# Altium schematic sheet [12] SPI.SchDoc("[12] SPI")
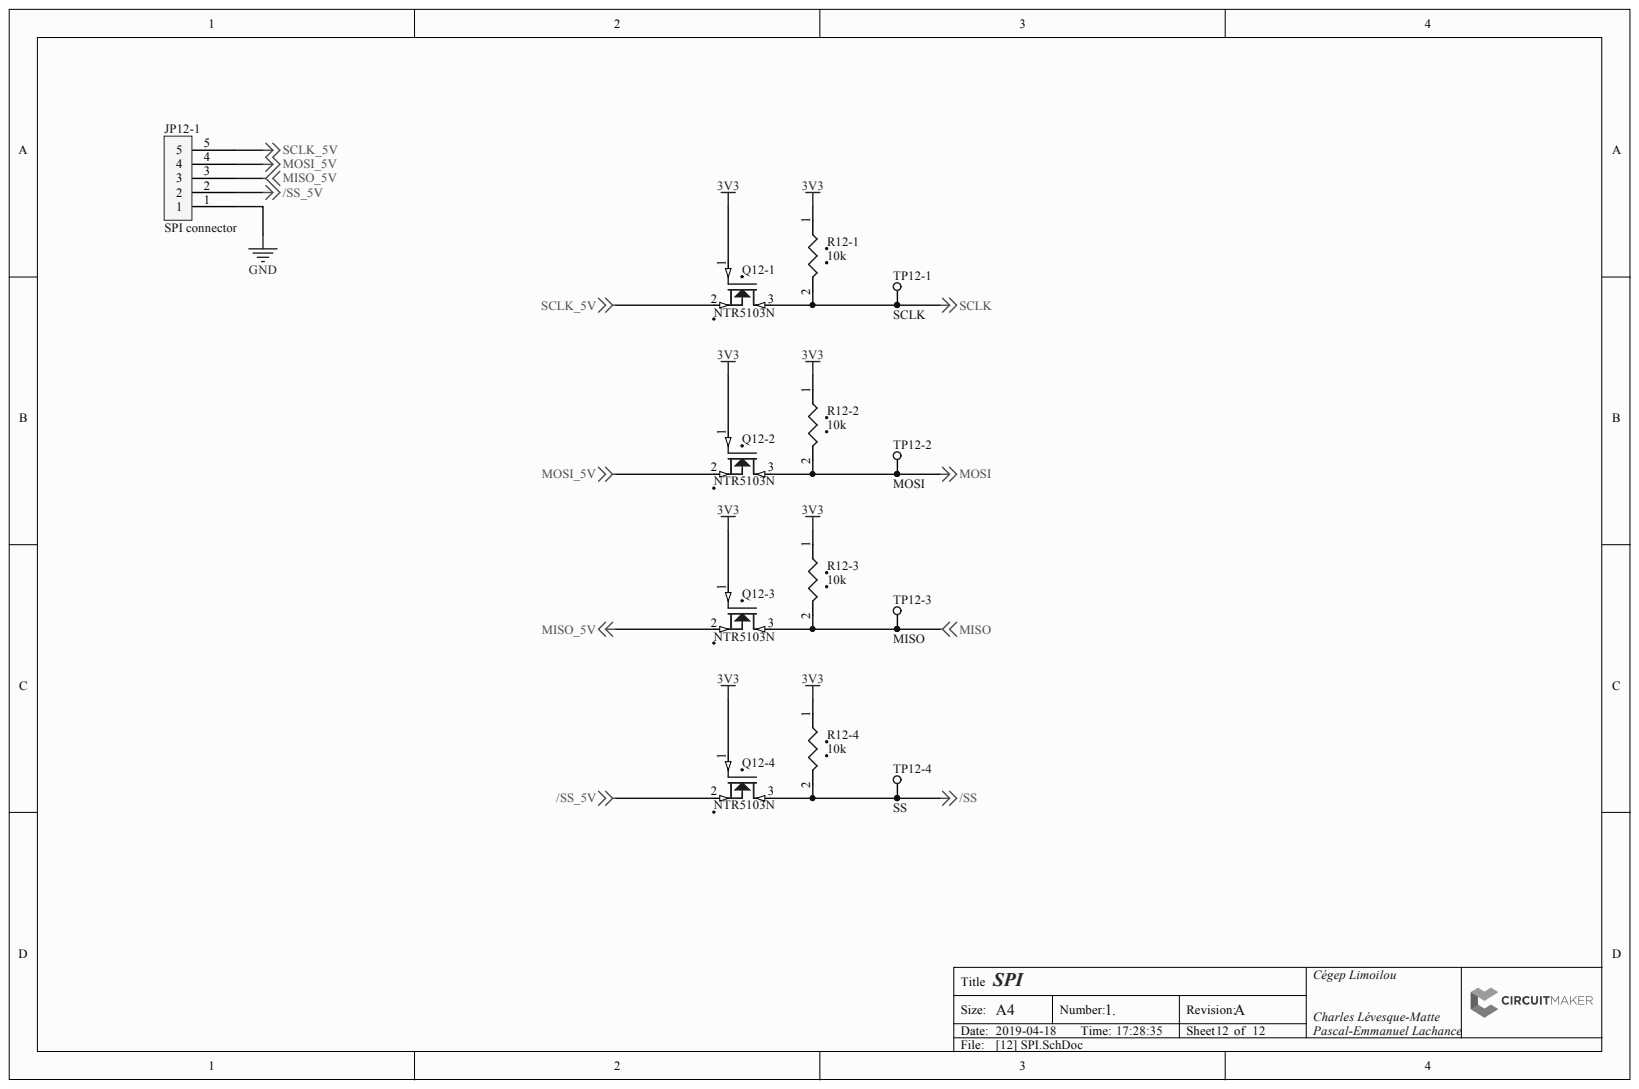
[diagram p0: [12] SPI.SchDoc]

## Parts
JP12-1  SPI connector  [PCB-egw8bqjg77gq9gnxyig3-1]
Q12-1  NTR5103N  [PCB-zphymqsti4y0133kiaza-1]
Q12-2  NTR5103N  [PCB-zphymqsti4y0133kiaza-1]
Q12-3  NTR5103N  [PCB-zphymqsti4y0133kiaza-1]
Q12-4  NTR5103N  [PCB-zphymqsti4y0133kiaza-1]
R12-1  10k  [PCB-cq2rvmn74vesaj8wmyk0-1]
R12-2  10k  [PCB-cq2rvmn74vesaj8wmyk0-1]
R12-3  10k  [PCB-cq2rvmn74vesaj8wmyk0-1]
R12-4  10k  [PCB-cq2rvmn74vesaj8wmyk0-1]
TP12-1  SCLK  [PCB-a7rpxwhzqdkz91v608la-1]
TP12-2  MOSI  [PCB-a7rpxwhzqdkz91v608la-1]
TP12-3  MISO  [PCB-a7rpxwhzqdkz91v608la-1]
TP12-4  SS  [PCB-a7rpxwhzqdkz91v608la-1]

## Nets
3V3: Q12-1.1 Q12-2.1 Q12-3.1 Q12-4.1 R12-1.1 R12-2.1 R12-3.1 R12-4.1
/SS: Q12-4.3 R12-4.2 TP12-4.1
/SS_5V: JP12-1.2 Q12-4.2
GND: JP12-1.1
MISO: Q12-3.3 R12-3.2 TP12-3.1
MISO_5V: JP12-1.3 Q12-3.2
MOSI: Q12-2.3 R12-2.2 TP12-2.1
MOSI_5V: JP12-1.4 Q12-2.2
SCLK: Q12-1.3 R12-1.2 TP12-1.1
SCLK_5V: JP12-1.5 Q12-1.2

## Library data of the parts
JP12-1 = CMP-1785def8bd83c842-2: Conn Shrouded Header HDR 5 POS 2.54mm Solder RA Thru-Hole; Component Kind: Standard; Library Name: CommunityVault; OctopartID: 1785def8bd83c842; Octopart URL: http://octopart.com/0705530004-molex-7835488; RoHS: Compliant
Q12-1-Q12-4 = CMP-02f4c92c4c09dd33-5: Manufacturer Part Number: NTR5103NT1G; Lead-Free Status: Lead Free; Polarity: N-Channel; Case/Package: TO-236, SC-59, SOT-23-3; Component Kind: Standard; Library Name: CommunityVault; Lifecycle Status: Active; Mounting Style: Surface Mount; OctopartID: 02f4c92c4c09dd33; Octopart URL: https://octopart.com/ntr5103nt1g-on+semiconductor-47344539; Packaging: Tape & Reel (TR); RoHS: Compliant
R12-1-R12-4 = CMP-02dfcaf00d3bdd18-3: Manufacturer Part Number: RMCF0603FT10K0; Lead-Free Status: Lead Free; Power Rating: 100 mW; Resistance: 10.0 kΩ; Resistance Tolerance: ±1%; Case/Package: 0603; Component Kind: Standard; Library Name: CommunityVault; Mounting Style: Surface Mount; OctopartID: 02dfcaf00d3bdd18; Octopart URL: http://octopart.com/rmcf0603ft10k0-stackpole+electronics-19212930; Packaging: Tape & Reel (TR); RoHS: Compliant
TP12-1-TP12-4 = CMP-5j384tb079h9dvgbp04a-2: Through-hole Testpoint; Component Kind: Standard; Library Name: CommunityVault
